# Altium schematic sheet 4G Modem2.SchDoc("4G Modem2")
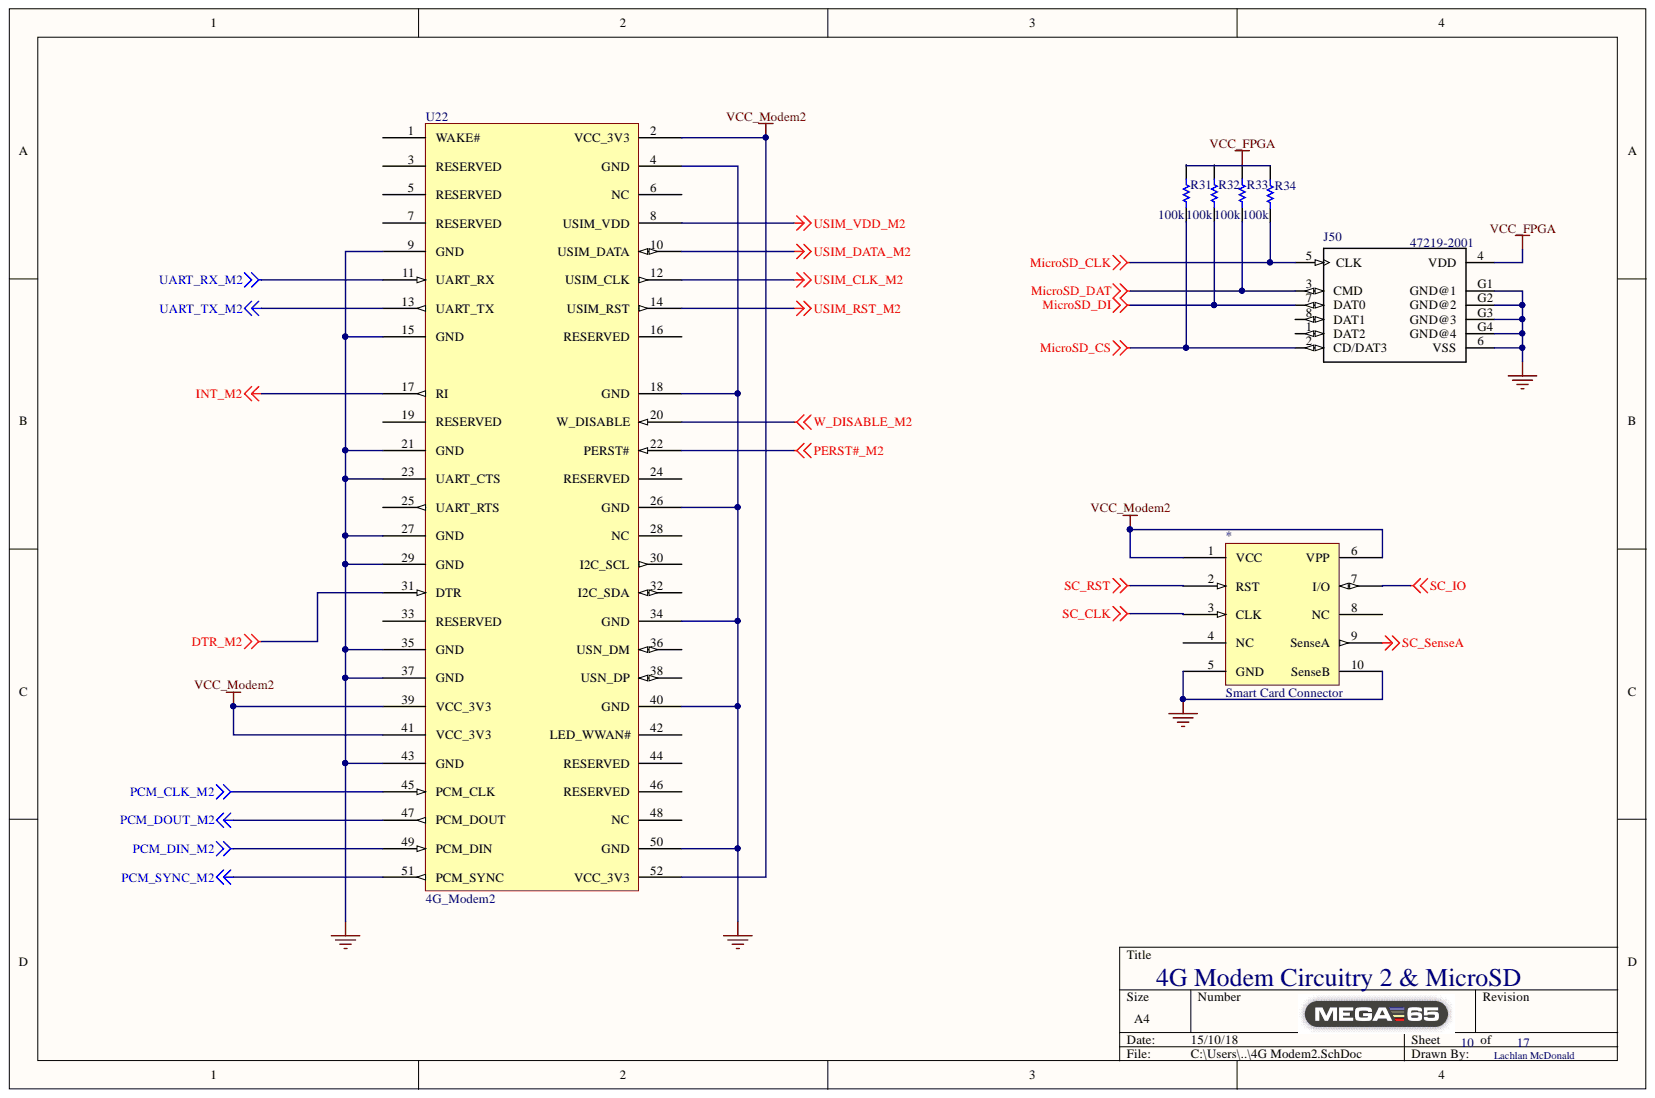
[diagram p0: 4G Modem2.SchDoc]

## Parts
*  Smart Card Connector  [Smart Card Connector]
J50  47219-2001  [MOLEX_47219-2001_47219-2001(Primary)]
R31  100k  [RESC0805(2012)_M]
R32  100k  [RESC0805(2012)_M]
R33  100k  [RESC0805(2012)_M]
R34  100k  [RESC0805(2012)_M]
U22  4G_Modem2  [MOLEX_67910001]

## Nets
DTR_M2: U22.31(DTR)
GND: *.5(GND) *.10(SenseB) J50.6(VSS) J50.G1(GND@1) J50.G2(GND@2) J50.G3(GND@3) J50.G4(GND@4) U22.4(GND) U22.9(GND) U22.15(GND) U22.18(GND) U22.21(GND) U22.23(UART_CTS) U22.26(GND) U22.27(GND) U22.29(GND) U22.34(GND) U22.35(GND) U22.37(GND) U22.40(GND) U22.43(GND) U22.50(GND)
INT_M2: U22.17(RI)
MicroSD_CLK: J50.5(CLK) R34.2
MicroSD_CS: J50.2(CD/DAT3) R31.2
MicroSD_DAT: J50.3(CMD) R33.2
MicroSD_DI: J50.7(DAT0) R32.2
PCM_CLK_M2: U22.45(PCM_CLK)
PCM_DIN_M2: U22.49(PCM_DIN)
PCM_DOUT_M2: U22.47(PCM_DOUT)
PCM_SYNC_M2: U22.51(PCM_SYNC)
PERST#_M2: U22.22(PERST#)
SC_CLK: *.3(CLK)
SC_IO: *.7(I/O)
SC_RST: *.2(RST)
SC_SenseA: *.9(SenseA)
UART_RX_M2: U22.11(UART_RX)
UART_TX_M2: U22.13(UART_TX)
USIM_CLK_M2: U22.12(USIM_CLK)
USIM_DATA_M2: U22.10(USIM_DATA)
USIM_RST_M2: U22.14(USIM_RST)
USIM_VDD_M2: U22.8(USIM_VDD)
VCC_FPGA: J50.4(VDD) R33.1
VCC_Modem2: *.1(VCC) *.6(VPP) U22.2(VCC_3V3) U22.39(VCC_3V3) U22.41(VCC_3V3) U22.52(VCC_3V3)
W_DISABLE_M2: U22.20(W_DISABLE)
NetR31_1: R31.1 R34.1

Not connected: * 4(NC) 8(NC); J50 1(DAT2) 8(DAT1); R32 1; U22 1(WAKE#) 3(RESERVED) 5(RESERVED) 6(NC) 7(RESERVED) 16(RESERVED) 19(RESERVED) 24(RESERVED) 25(UART_RTS) 28(NC) 30(I2C_SCL) 32(I2C_SDA) 33(RESERVED) 36(USN_DM) 38(USN_DP) 42(LED_WWAN#) 44(RESERVED) 46(RESERVED) 48(NC)

## Library data of the parts
J50 = 47219-2001: Conn Micro SD Card SKT 8 POS 1.1mm Solder RA SMD 0.5A/Contact Embossed T/R; MF: Molex; SnapEDA_Link: https://www.snapeda.com/parts/47219-2001/Molex/view-part/?ref=snap; Price: None; Package: None; MP: 47219-2001; Library Name: 47219-2001.IntLib; Component Kind: Standard; Availability: Unavailable
R31-R34 = CMP-1013-00623-1: 10K 0.125W 1% 0805 (2012 Metric)  SMD; Value: 10000.00 Ohm; Case-Metric: 2012; Case-EIA: 0805; Tolerance: 1 %; Technology: SMT; Power: 0.125 W; Library Name: Altium Content Vault; Component Kind: Standard
U22 = 4G_Modem: Library Name: Schlib1.SchLib; Component Kind: Standard
* = Smart Card Connector: Library Name: Schlib1.SchLib; Component Kind: Standard
